# Altium schematic sheet SW2.SchDoc
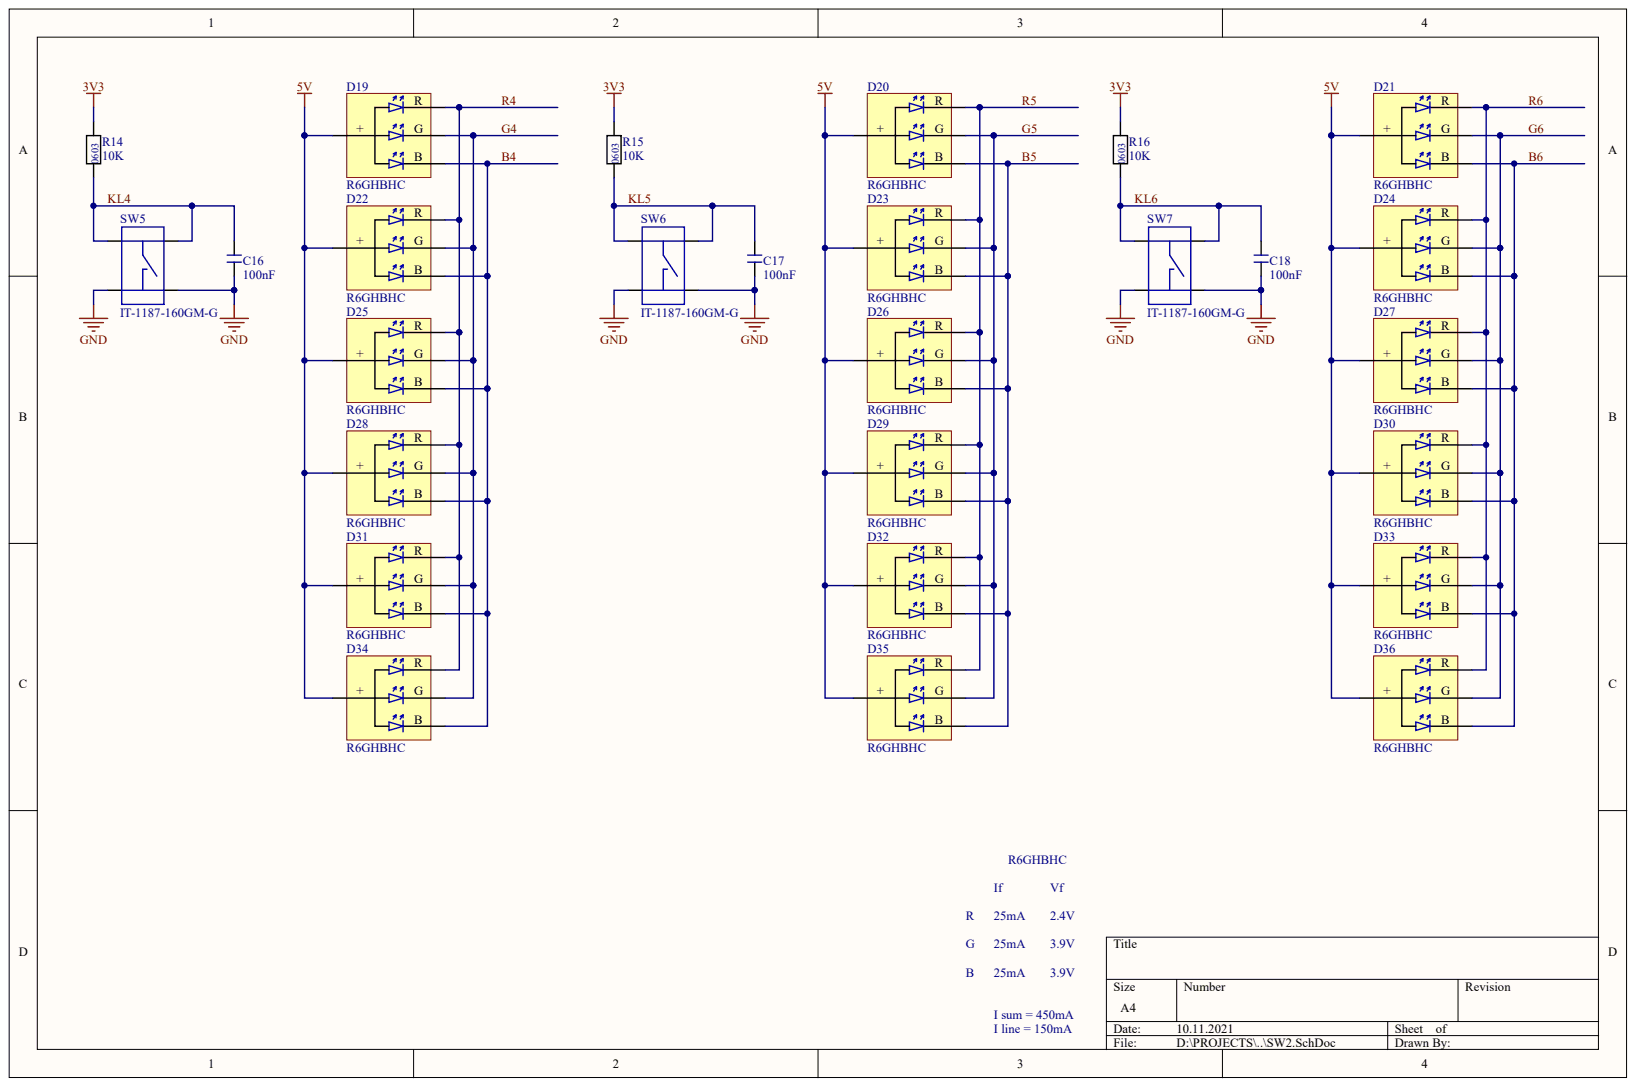
[diagram p0: SW2.SchDoc]

## Parts
C16  100nF
C17  100nF
C18  100nF
D19  R6GHBHC
D20  R6GHBHC
D21  R6GHBHC
D22  R6GHBHC
D23  R6GHBHC
D24  R6GHBHC
D25  R6GHBHC
D26  R6GHBHC
D27  R6GHBHC
D28  R6GHBHC
D29  R6GHBHC
D30  R6GHBHC
D31  R6GHBHC
D32  R6GHBHC
D33  R6GHBHC
D34  R6GHBHC
D35  R6GHBHC
D36  R6GHBHC
R14  10K
R15  10K
R16  10K
SW5  IT-1187-160GM-G
SW6  IT-1187-160GM-G
SW7  IT-1187-160GM-G

## Nets
3V3: R14.2 R15.2 R16.2
5V: D19.+ D20.+ D21.+ D22.+ D23.+ D24.+ D25.+ D26.+ D27.+ D28.+ D29.+ D30.+ D31.+ D32.+ D33.+ D34.+ D35.+ D36.+
GND: C16.1 C17.1 C18.1 SW5.3 SW5.4 SW6.3 SW6.4 SW7.3 SW7.4
B4: D19.B D22.B D25.B D28.B D31.B D34.B
B5: D20.B D23.B D26.B D29.B D32.B D35.B
B6: D21.B D24.B D27.B D30.B D33.B D36.B
G4: D19.G D22.G D25.G D28.G D31.G D34.G
G5: D20.G D23.G D26.G D29.G D32.G D35.G
G6: D21.G D24.G D27.G D30.G D33.G D36.G
KL4: C16.2 R14.1 SW5.1 SW5.2
KL5: C17.2 R15.1 SW6.1 SW6.2
KL6: C18.2 R16.1 SW7.1 SW7.2
R4: D19.R D22.R D25.R D28.R D31.R D34.R
R5: D20.R D23.R D26.R D29.R D32.R D35.R
R6: D21.R D24.R D27.R D30.R D33.R D36.R
